# Altium schematic sheet sensor.SchDoc(sensor)
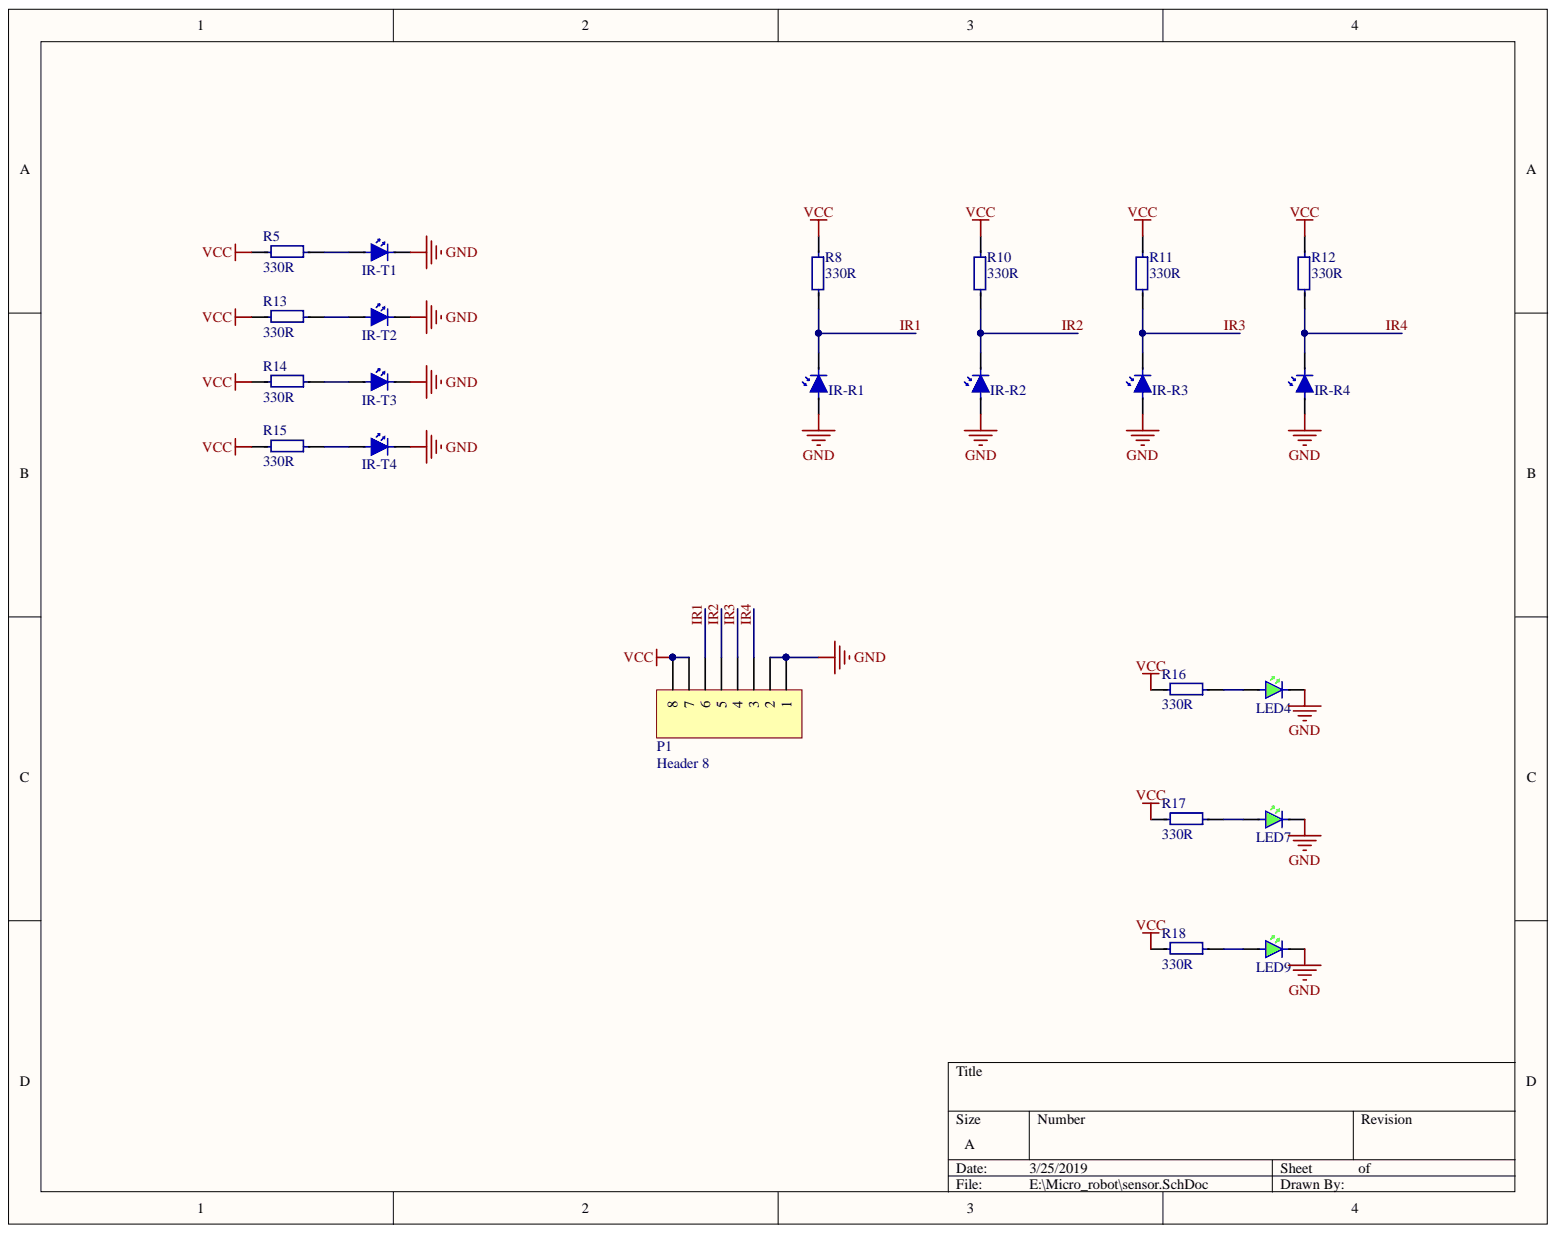
[diagram p0: sensor.SchDoc]

## Parts
IR-R1  IR-R  [IR-R]
IR-R2  IR-R  [IR-R]
IR-R3  IR-R  [IR-R]
IR-R4  IR-R  [IR-R]
IR-T1  IR-T  [IR-T]
IR-T2  IR-T  [IR-T]
IR-T3  IR-T  [IR-T]
IR-T4  IR-T  [IR-T]
LED4  LED_SMD  [LED-SMD]  (value not drawn)
LED7  LED_SMD  [LED-SMD]  (value not drawn)
LED9  LED_SMD  [LED-SMD]  (value not drawn)
P1  Header 8  [HDR1X8]
R5  330R  [RES-SMD-805]
R8  330R  [RES-SMD-805]
R10  330R  [RES-SMD-805]
R11  330R  [RES-SMD-805]
R12  330R  [RES-SMD-805]
R13  330R  [RES-SMD-805]
R14  330R  [RES-SMD-805]
R15  330R  [RES-SMD-805]
R16  330R  [RES-SMD-805]
R17  330R  [RES-SMD-805]
R18  330R  [RES-SMD-805]

## Nets
GND: IR-R1.A IR-R2.A IR-R3.A IR-R4.A IR-T1.K IR-T2.K IR-T3.K IR-T4.K LED4.K LED7.K LED9.K P1.1 P1.2
VCC: P1.7 P1.8 R5.1 R8.2 R10.2 R11.2 R12.2 R13.1 R14.1 R15.1 R16.1 R17.1 R18.1
IR1: IR-R1.K P1.6 R8.1
IR2: IR-R2.K P1.5 R10.1
IR3: IR-R3.K P1.4 R11.1
IR4: IR-R4.K P1.3 R12.1
NetIR-T1_A: IR-T1.A R5.2
NetIR-T2_A: IR-T2.A R13.2
NetIR-T3_A: IR-T3.A R14.2
NetIR-T4_A: IR-T4.A R15.2
NetLED4_A: LED4.A R16.2
NetLED7_A: LED7.A R17.2
NetLED9_A: LED9.A R18.2

## Library data of the parts
LED4 LED7 LED9 = LED-SMD: Library Name: 3D Library.SchLib; Component Kind: Standard
P1 = Header 8: Header, 8-Pin; Signal Integrity: Connector; Library Name: Miscellaneous Connectors.IntLib; LatestRevisionNote: Re-released for DXP Platform.; LatestRevisionDate: 17-Jul-2002; Component Kind: Standard; Publisher: Altium Limited
R5 R8 R10-R18 = RES-SMD: Library Name: 3D Library.SchLib; Component Kind: Standard
IR-R1-IR-R4 = IR-R: Library Name: 3D Library.SchLib; Component Kind: Standard
IR-T1-IR-T4 = IR-T: Library Name: 3D Library.SchLib; Component Kind: Standard
